# Altium schematic sheet I-O Connectors.SchDoc
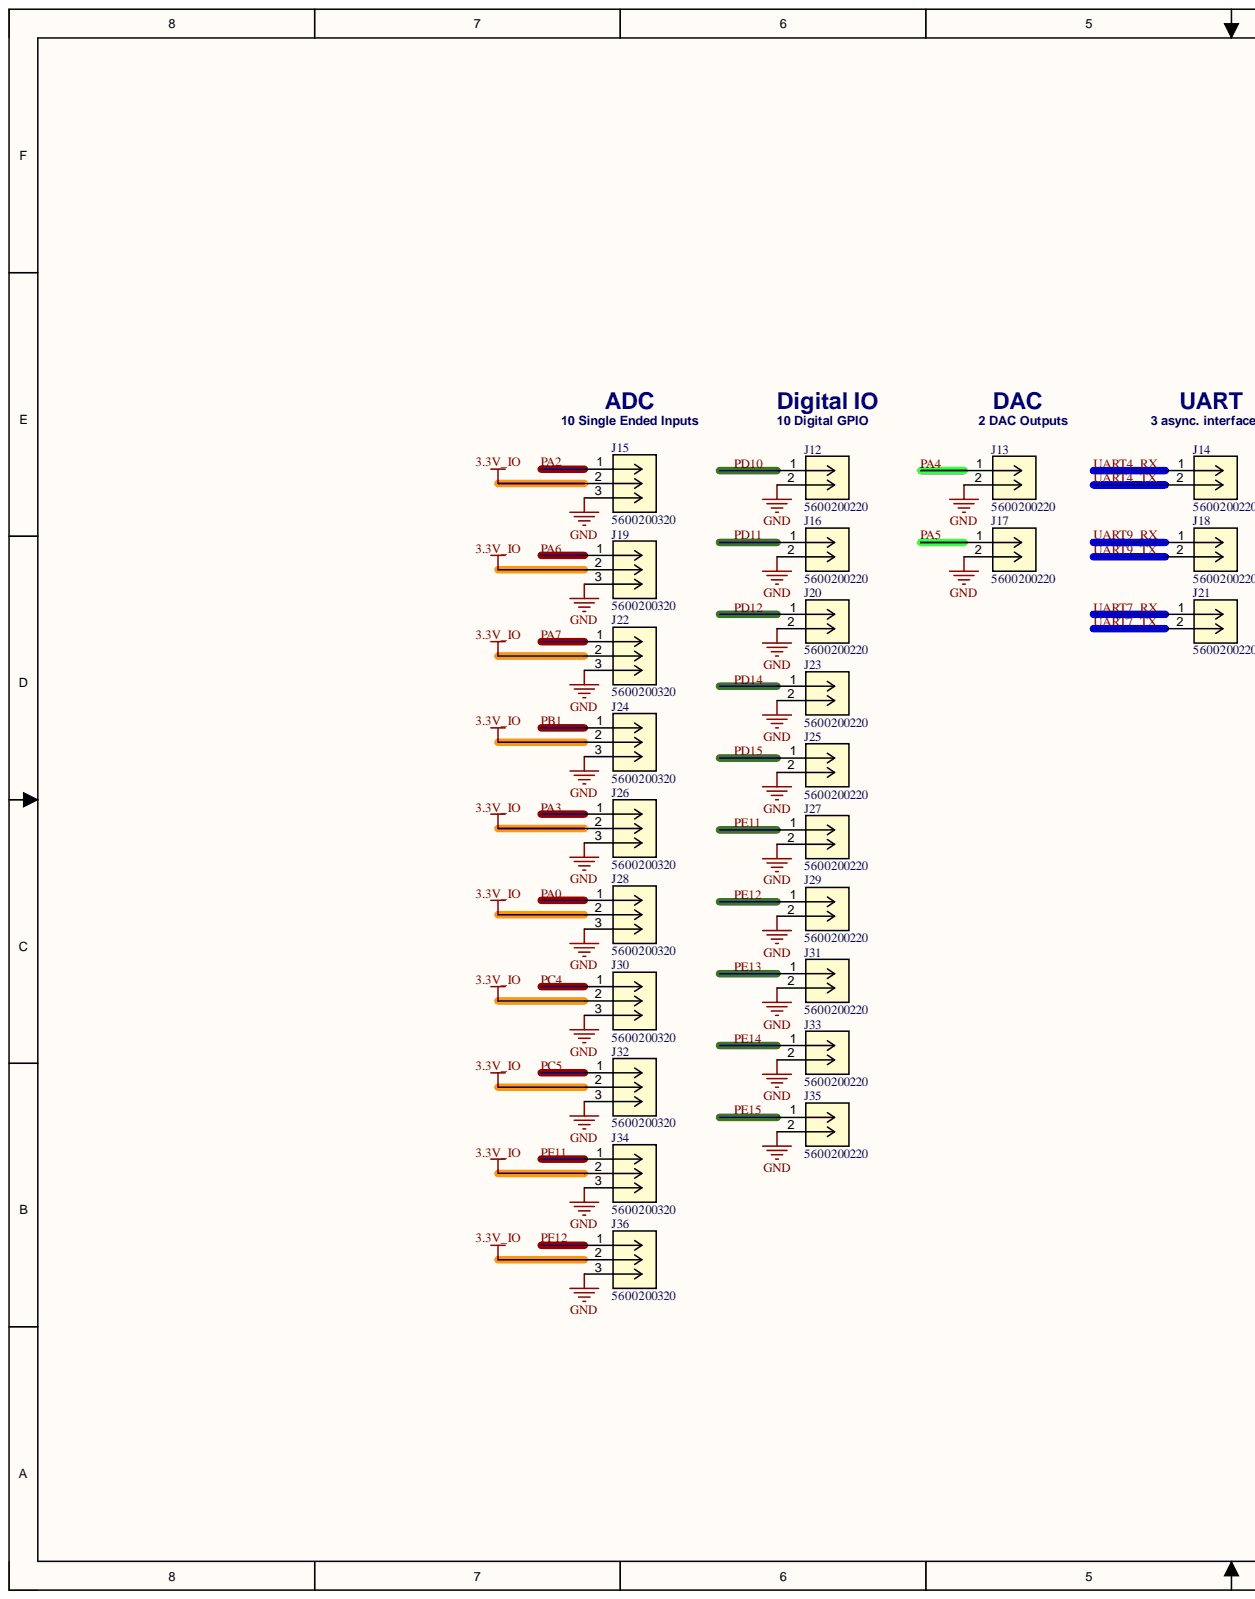
[diagram p0: I-O Connectors.SchDoc, left]
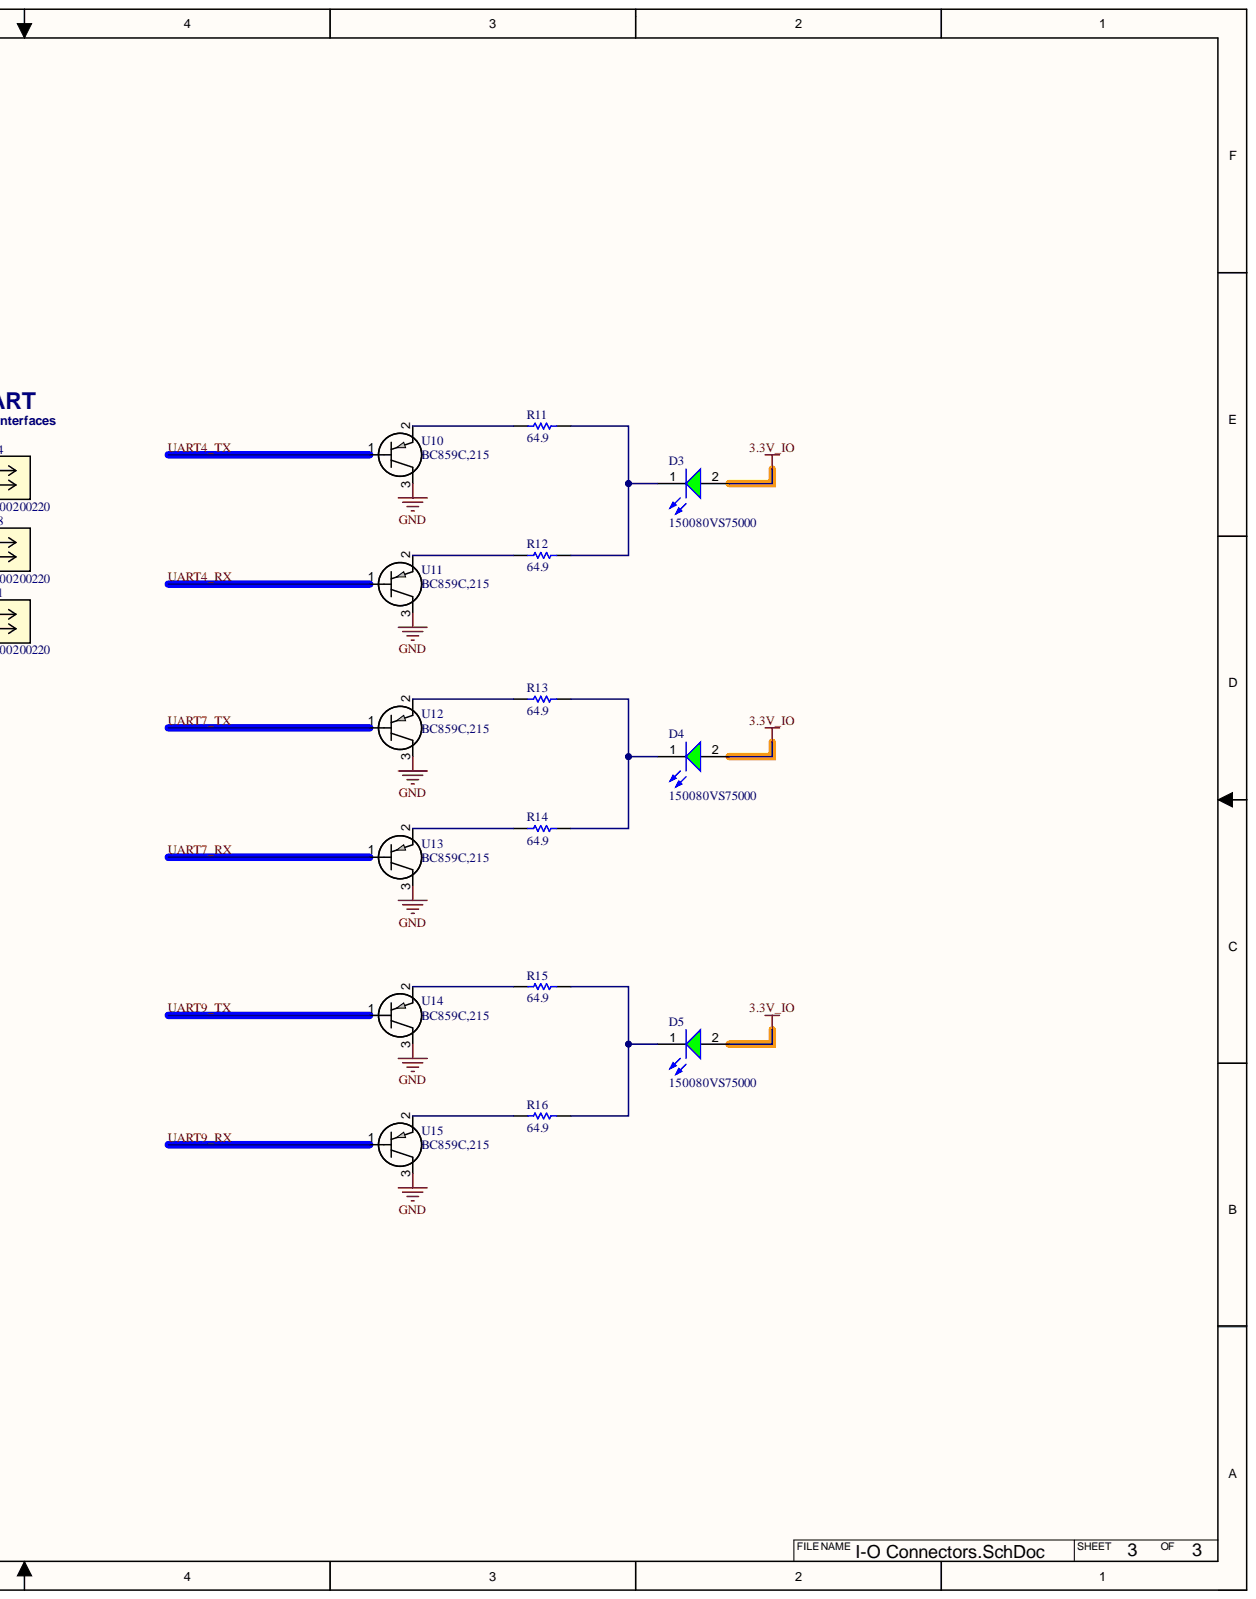
[diagram p1: I-O Connectors.SchDoc, right]

## Parts
D3  150080VS75000  [WL-SMCW_0805_150080xx75000]
D4  150080VS75000  [WL-SMCW_0805_150080xx75000]
D5  150080VS75000  [WL-SMCW_0805_150080xx75000]
J12  5600200220  [CONN_5600200220_MOL]
J13  5600200220  [CONN_5600200220_MOL]
J14  5600200220  [CONN_5600200220_MOL]
J15  5600200320  [CONN03_560020_MOL]
J16  5600200220  [CONN_5600200220_MOL]
J17  5600200220  [CONN_5600200220_MOL]
J18  5600200220  [CONN_5600200220_MOL]
J19  5600200320  [CONN03_560020_MOL]
J20  5600200220  [CONN_5600200220_MOL]
J21  5600200220  [CONN_5600200220_MOL]
J22  5600200320  [CONN03_560020_MOL]
J23  5600200220  [CONN_5600200220_MOL]
J24  5600200320  [CONN03_560020_MOL]
J25  5600200220  [CONN_5600200220_MOL]
J26  5600200320  [CONN03_560020_MOL]
J27  5600200220  [CONN_5600200220_MOL]
J28  5600200320  [CONN03_560020_MOL]
J29  5600200220  [CONN_5600200220_MOL]
J30  5600200320  [CONN03_560020_MOL]
J31  5600200220  [CONN_5600200220_MOL]
J32  5600200320  [CONN03_560020_MOL]
J33  5600200220  [CONN_5600200220_MOL]
J34  5600200320  [CONN03_560020_MOL]
J35  5600200220  [CONN_5600200220_MOL]
J36  5600200320  [CONN03_560020_MOL]
R11  64.9  [RESC2013X60X35LL10T20]
R12  64.9  [RESC2013X60X35LL10T20]
R13  64.9  [RESC2013X60X35LL10T20]
R14  64.9  [RESC2013X60X35LL10T20]
R15  64.9  [RESC2013X60X35LL10T20]
R16  64.9  [RESC2013X60X35LL10T20]
U10  BC859C,215  [SOT23_NEX]
U11  BC859C,215  [SOT23_NEX]
U12  BC859C,215  [SOT23_NEX]
U13  BC859C,215  [SOT23_NEX]
U14  BC859C,215  [SOT23_NEX]
U15  BC859C,215  [SOT23_NEX]

## Nets
3.3V_IO: D3.2 D4.2 D5.2 J15.2 J19.2 J22.2 J24.2 J26.2 J28.2 J30.2 J32.2 J34.2 J36.2
GND: J12.2 J13.2 J15.3 J16.2 J17.2 J19.3 J20.2 J22.3 J23.2 J24.3 J25.2 J26.3 J27.2 J28.3 J29.2 J30.3 J31.2 J32.3 J33.2 J34.3 J35.2 J36.3 U10.3 U11.3 U12.3 U13.3 U14.3 U15.3
PA0: J28.1
PA2: J15.1
PA3: J26.1
PA4: J13.1
PA5: J17.1
PA6: J19.1
PA7: J22.1
PB1: J24.1
PC4: J30.1
PC5: J32.1
PD10: J12.1
PD11: J16.1
PD12: J20.1
PD14: J23.1
PD15: J25.1
PE11: J27.1
PE12: J29.1
PE13: J31.1
PE14: J33.1
PE15: J35.1
PF11: J34.1
PF12: J36.1
UART4_RX: J14.1 U11.1
UART4_TX: J14.2 U10.1
UART7_RX: J21.1 U13.1
UART7_TX: J21.2 U12.1
UART9_RX: J18.1 U15.1
UART9_TX: J18.2 U14.1
NetD3_1: D3.1 R11.1 R12.1
NetD4_1: D4.1 R13.1 R14.1
NetD5_1: D5.1 R15.1 R16.1
NetR11_2: R11.2 U10.2
NetR12_2: R12.2 U11.2
NetR13_2: R13.2 U12.2
NetR14_2: R14.2 U13.2
NetR15_2: R15.2 U14.2
NetR16_2: R16.2 U15.2

## Library data of the parts
D3-D5 = CMP-1426-00009-6: WL-SMCW SMT Mono-Color Chip LED Waterclear; Color: Green; Dominant Wavelength: 570nm; Forward Current: 100mA; Forward Voltage: 2V; Lens Style: Clear, Rectangular; Luminous Intensity: 40mcd; Max Junction Temperature (Tj): 95°C; Max Reverse Voltage (DC): 5V; Peak Wavelength: 572nm; Power Dissipation: 72mW; Test Current: 20mA; Viewing Angle: 140°; Case Code (Imperial): 0805; Case Code (Metric): 2012; Case/Package: 0805; Component Kind: Standard; Height: 700µm; Length: 2mm; Library Name: Altium Content Vault; Max Operating Temperature: 85°C; Min Operating Temperature: -40°C; Mount: Surface Mount; Packaging: Tape and Reel; REACH SVHC: Unknown; Reverse Voltage: 5V; RoHS: Compliant; Wavelength: 570nm; Width: 1.25mm
J12-J14 J16-J18 J20 J21 J23 J25 J27 J29 J31 J33 J35 = 5600200220: No Description Available; Mfr_Name: Molex Connector Corporation; Component Kind: Standard; Library Name: 5600200220.SchLib; RefDes: RefDes; Type: Type
J15 J19 J22 J24 J26 J28 J30 J32 J34 J36 = 5600200320: No Description Available; Mfr_Name: Molex Connector Corporation; Component Kind: Standard; Library Name: 5600200320.SchLib; RefDes: RefDes; Type: Type
R11-R16 = CMP-2001-01223-1: Manufacturer: Yageo; Part Number: RC0805FR-0764R9L; Component Kind: Standard; Library Name: Altium Content Vault
U10-U15 = BC859C,215: No Description Available; Mfr_Name: Nexperia; Component Kind: Standard; Library Name: BC859C,215.SchLib
